# Altium schematic sheet Master.SchDoc
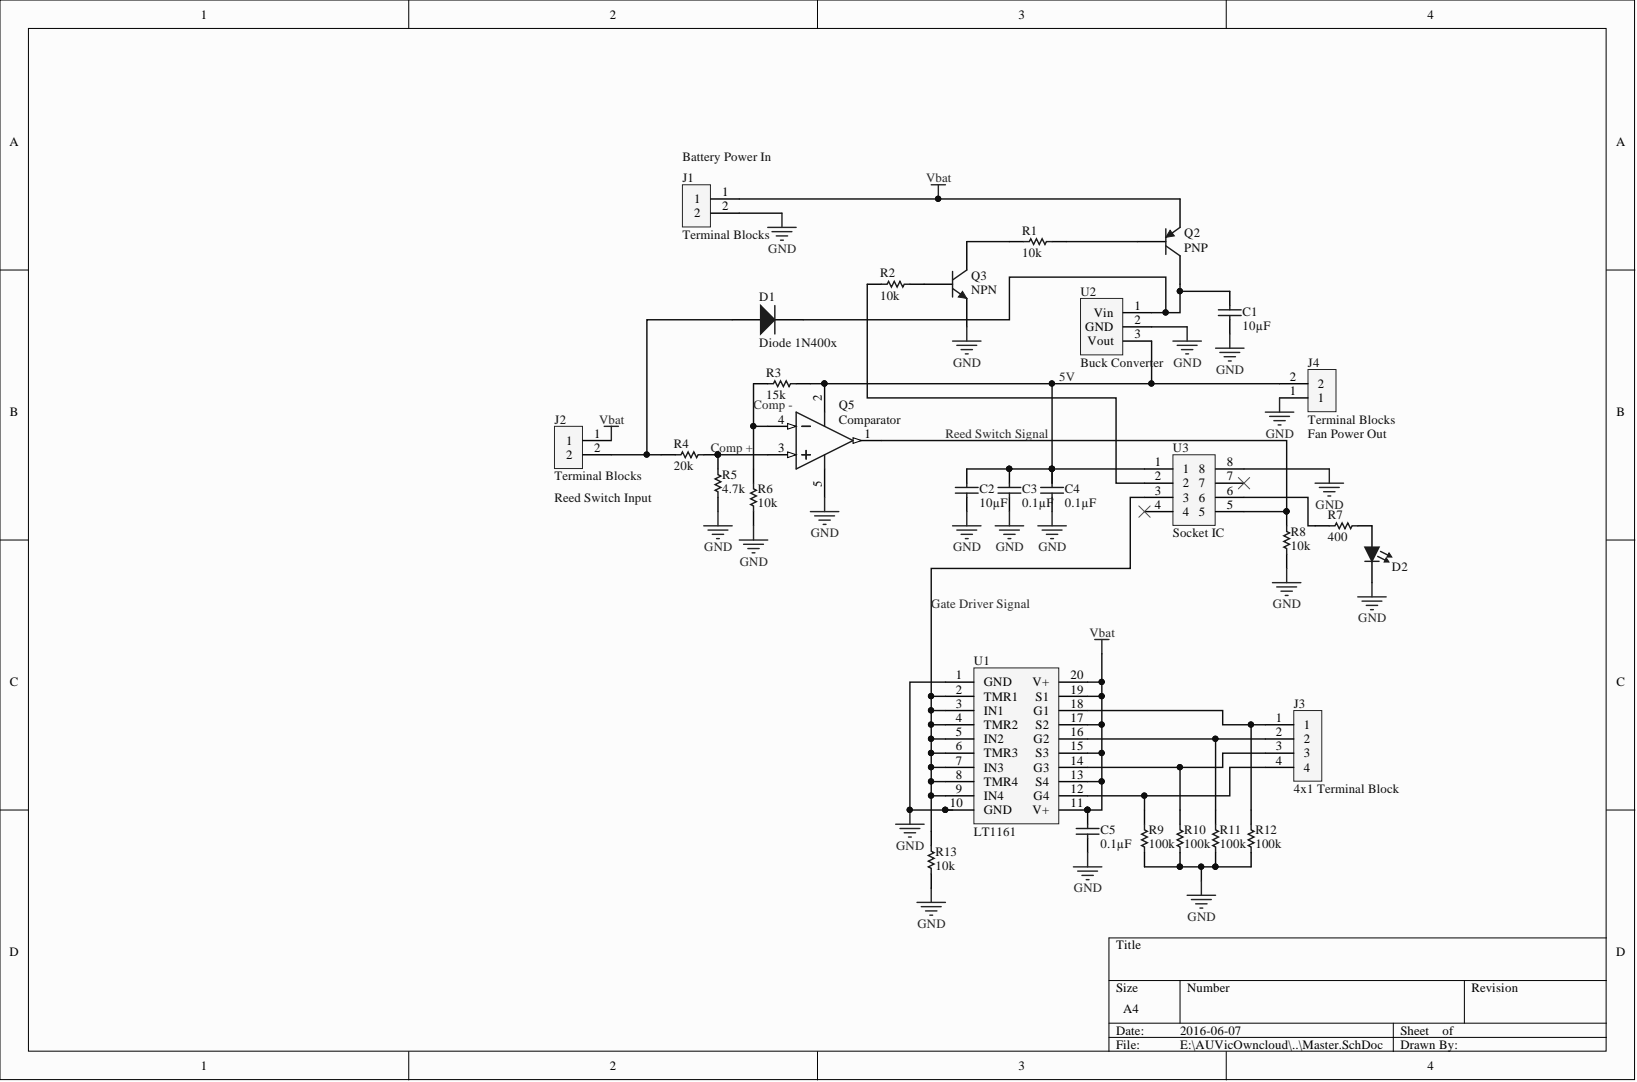
[diagram p0: Master.SchDoc]

## Parts
C1  10µF  [CAPC3216N(1206")]
C2  10µF  [CAPC3216N(1206")]
C3  Capacitance: 0.1µF  [CAPC1608N(0603")]  (hidden comment: 0.1uF, 50V, 10%, X7R, 1608)
C4  Capacitance: 0.1µF  [CAPC1608N(0603")]  (hidden comment: 0.1uF, 50V, 10%, X7R, 1608)
C5  Capacitance: 0.1µF  [CAPC1608N(0603")]  (hidden comment: 0.1uF, 50V, 10%, X7R, 1608)
D1  Diode 1N400x  [Diode]
D2  Red  [LED0603]  (value not drawn)
J1  Terminal Blocks  [2X1 Terminal Blocks - duplicate]
J2  Terminal Blocks  [2X1 Terminal Blocks - duplicate]
J3  4x1 Terminal Block  [4X1 Terminal Block]
J4  Terminal Blocks  [2X1 Terminal Blocks - duplicate]
Q2  PNP  [PNP (MMBTA64LT1GOSCT-ND  )]
Q3  NPN  [NPN (MMBT2222ALT1GOSCT-ND)]
Q5  Comparator  [Compartor (576-1318-1-ND)]
R1  10k  [Resistor 1206]
R2  10k  [RESC1608N(0603")]
R3  15k  [RES 0805]
R4  20k  [RES 0805]
R5  4.7k  [RESC2012N(0805")]
R6  10k  [RESC1608N(0603")]
R7  400  [Resistor 1206]
R8  10k  [RESC1608N(0603")]
R9  100k  [Resistor 1206]
R10  100k  [Resistor 1206]
R11  100k  [Resistor 1206]
R12  100k  [Resistor 1206]
R13  10k  [Resistor 1206]
U1  LT1161  [LT1161]
U2  Buck Converter  [Buck Converter]
U3  Socket IC  [PIC 8-DIP]

## Nets
GND: C1.1 C2.1 C3.1 C4.1 C5.1 D2.2 J1.2 J4.1 Q3.2 Q5.5 R5.1 R6.1 R8.1 R9.1 R10.1 R11.1 R12.1 R13.1 U1.1(GND) U1.10(GND) U2.2 U3.8
VBAT: C5.2 J1.1 J2.1 Q2.2 U1.11(V+) U1.13(S4) U1.15(S3) U1.17(S2) U1.19(S1) U1.20(V+)
5V: C2.2 C3.2 C4.2 J4.2 Q5.2 R3.1 U2.3 U3.1
COMP -: Q5.4 R3.2 R6.2
GATE DRIVER SIGNAL: R13.2 U1.2(TMR1) U1.3(IN1) U1.4(TMR2) U1.5(IN2) U1.6(TMR3) U1.7(IN3) U1.8(TMR4) U1.9(IN4) U3.3
REED SWITCH SIGNAL: Q5.1 R8.2 U3.5
NetC1_2: C1.2 D1.K Q2.3 U2.1
NetD1_A: D1.A J2.2 R4.1
NetD2_1: D2.1 R7.2
NetJ3_1: J3.1 R12.2 U1.18(G1)
NetJ3_2: J3.2 R11.2 U1.16(G2)
NetJ3_3: J3.3 R10.2 U1.14(G3)
NetJ3_4: J3.4 R9.2 U1.12(G4)
NetQ2_1: Q2.1 R1.2
NetQ3_1: Q3.1 R2.2
NetQ3_3: Q3.3 R1.1
NetQ5_3: Q5.3 R4.2 R5.2
NetR2_1: R2.1 U3.2
NetR7_1: R7.1 U3.6
COMP +:

Not connected: U3 4 7

## Library data of the parts
C1 C2 = 10uF 50V 1206 SMD, Ceramic: Capacitor, Ceramic, 50V, +/- 10%, 1206 SMD, X5R; Component Kind: Standard; PackageDescription: Chip Capacitor; Published: 14-06-01; Supplier 1: Digi-Key; Supplier Part Number 1: 1276-2876-1-ND; Value: 10µF; Library Name: AUVic_Integrated_Library_Capacitors.IntLib; Publisher: AUVic
C3-C5 = 0.1uF, 50V, 10%, X7R, 1608: CAP CER 0.1UF 50V 10% X7R 1608 (0603); Manufacturer: Samsung Electro-Mechanics America, Inc; Manufacturer Part Number: CL10B104KB8SFNC; Applications: Boardflex Sensitive; Category: Capacitors; Component Kind: Standard; ComponentLink1Description: http://www.samsungsem.com/servlet/FileDownload?type=spec&file=CL10B104KB8SFNC.pdf; ComponentLink1URL: http://www.samsungsem.com/servlet/FileDownload?type=spec&file=CL10B104KB8SFNC.pdf; Features: Soft Termination; Height - Seated (Max): -; Lead Spacing: -; Lead Style: -; Mounting Type: Surface Mount, MLCC; Operating Temperature: -55°C ~ 125°C; Package / Case: 0603 (1608 Metric); Packaging: Cut Tape (CT); Pricing 1: 1=0.12, 10=0.033, 25=0.0232, 100=0.0152, 500=0.01064, 1000=0.00829 (CAD); Ratings: -; RoHS: RoHS Compliant; Size / Dimension: 0.063" L x 0.031" W (1.60mm x 0.80mm); Stock 1: 743572; Supplier 1: Digi-Key; Supplier Part Number 1: 1276-1936-1-ND; Temperature Coefficient: X7R; Thickness (Max): 0.035" (0.90mm); Tolerance: ±10%; Voltage - Rated: 50V; Library Name: AUVic_Integrated_Library_Capacitors.IntLib
D1 = Diode 1N400x: Through Hole Diode; Made by: Andy Bates; Component Kind: Standard; Library Name: Parts.SchLib; Supplier 1: Digikey; Supplier 1 Part Number: 1N4001
D2 = LED, Red, 0805 (2012) SMD, Clear: Colour: Red; Component Kind: Standard; Description: LED, Red, 0805 (2012) SMD, Clear; Supplier 1: Digi-Key; Supplier Part Number 1: 732-4984-1-ND; Library Name: AUVic_Integrated_Library_Diodes.IntLib
J1 J2 J4 = Terminal Blocks: 125V 10A Through Hole; Made by: Andy Bates; Component Kind: Standard; Library Name: Parts.SchLib; Supplier 1: Digikey; Supplier 1 Part Number: ED2740-ND
J3 = 4x1 Terminal Block: Made by: Andy Bates; Component Kind: Standard; Library Name: Parts.SchLib; Supplier 1: Digikey; Supplier 1 Part Number: ED2742-ND
Q2 = PNP: 30V 500mA 225mW SMD; Made by: Andy Bates; Component Kind: Standard; Library Name: Parts.SchLib; Supplier 1: Digikey; Supplier 1 Part Number: MMBTA64LT1GOSCT-ND
Q3 = NPN: 40V 600mA 300mW SMD; Made by: Andy Bates; Component Kind: Standard; Library Name: Parts.SchLib; Supplier 1: Digikey; Supplier 1 Part Number: MMBT2222ALT1GOSCT-ND
Q5 = Comparator: 2.2V ~ 10V; Made by: Andy Bates; Component Kind: Standard; Library Name: Parts.SchLib; Supplier 1: Digikey; Supplier 1 Part Number: 576-1318-1-ND
R1 R13 = 10k: Res 10k 1206 SMD; Made by: Andy Bates; Component Kind: Standard; Library Name: Parts.SchLib
R2 R6 R8 = 10kR, 1%, 1/10W, 0603 SMD: Made By: Ashwin Sira; Owned By: AUVic; Component Kind: Standard; Created On: 14-05-31; Description: 10kR, 1%, 1/10W, 0603 SMD; Last Edited: 14-05-31; Library Name: AUVic_Integrated_Library_Resistors.IntLib; Supplier 1: Digi-Key; Supplier Part Number 1: 311-10.0KHRCT-ND; Value: 10k
R3 = 15k: Res 15k, 0805, SMD; Made by: Andy Bates; Component Kind: Standard; Library Name: Parts.SchLib
R4 = 20k: Res 20k, 0805, SMD; Made by: Andy Bates; Component Kind: Standard; Library Name: Parts.SchLib
R5 = 4.7kR, 1%, 1/8W, 0805 SMD: Made By: Ashwin Sira; Owned By: AUVic; Component Kind: Standard; Created On: 14-05-31; Description: 4.7kR, 1%, 1/8W, 0805 SMD; Last Edited: 14-05-31; Library Name: AUVic_Integrated_Library_Resistors.IntLib; Supplier 1: Digi-Key; Supplier Part Number 1: RMCF0805FT4K70CT-ND; Value: 4.7k
R7 = 400: Res 400 ohm 1206 SMD; Made By: Andy Bates; Component Kind: Standard; Library Name: Parts.SchLib
R9-R12 = 100k: Res 100k, 1203, SMD; Made by: Andy Bates; Component Kind: Standard; Library Name: Parts.SchLib
U1 = LT1161: Gate Driver, 8V ~ 48V, 4 channels; Made by: Andy Bates; Component Kind: Standard; Library Name: Parts.SchLib; Supplier 1: Digikey; Supplier 1 Part Number: LT1161
U2 = Buck Converter: 8V~28V in, 5V out, 1A, 5W; Made by: Andy Bates; Component Kind: Standard; Library Name: Parts.SchLib; Supplier 1: Digikey; Supplier 1 Part Number: 945-2201-ND
U3 = Socket IC: DIP Socket IC, SMD; Made by: Andy Bates; Component Kind: Standard; Library Name: Parts.SchLib; Supplier 1: Digikey; Supplier 1 Part Number: 1212-1032-ND
